# Altium schematic sheet ACW.SchDoc
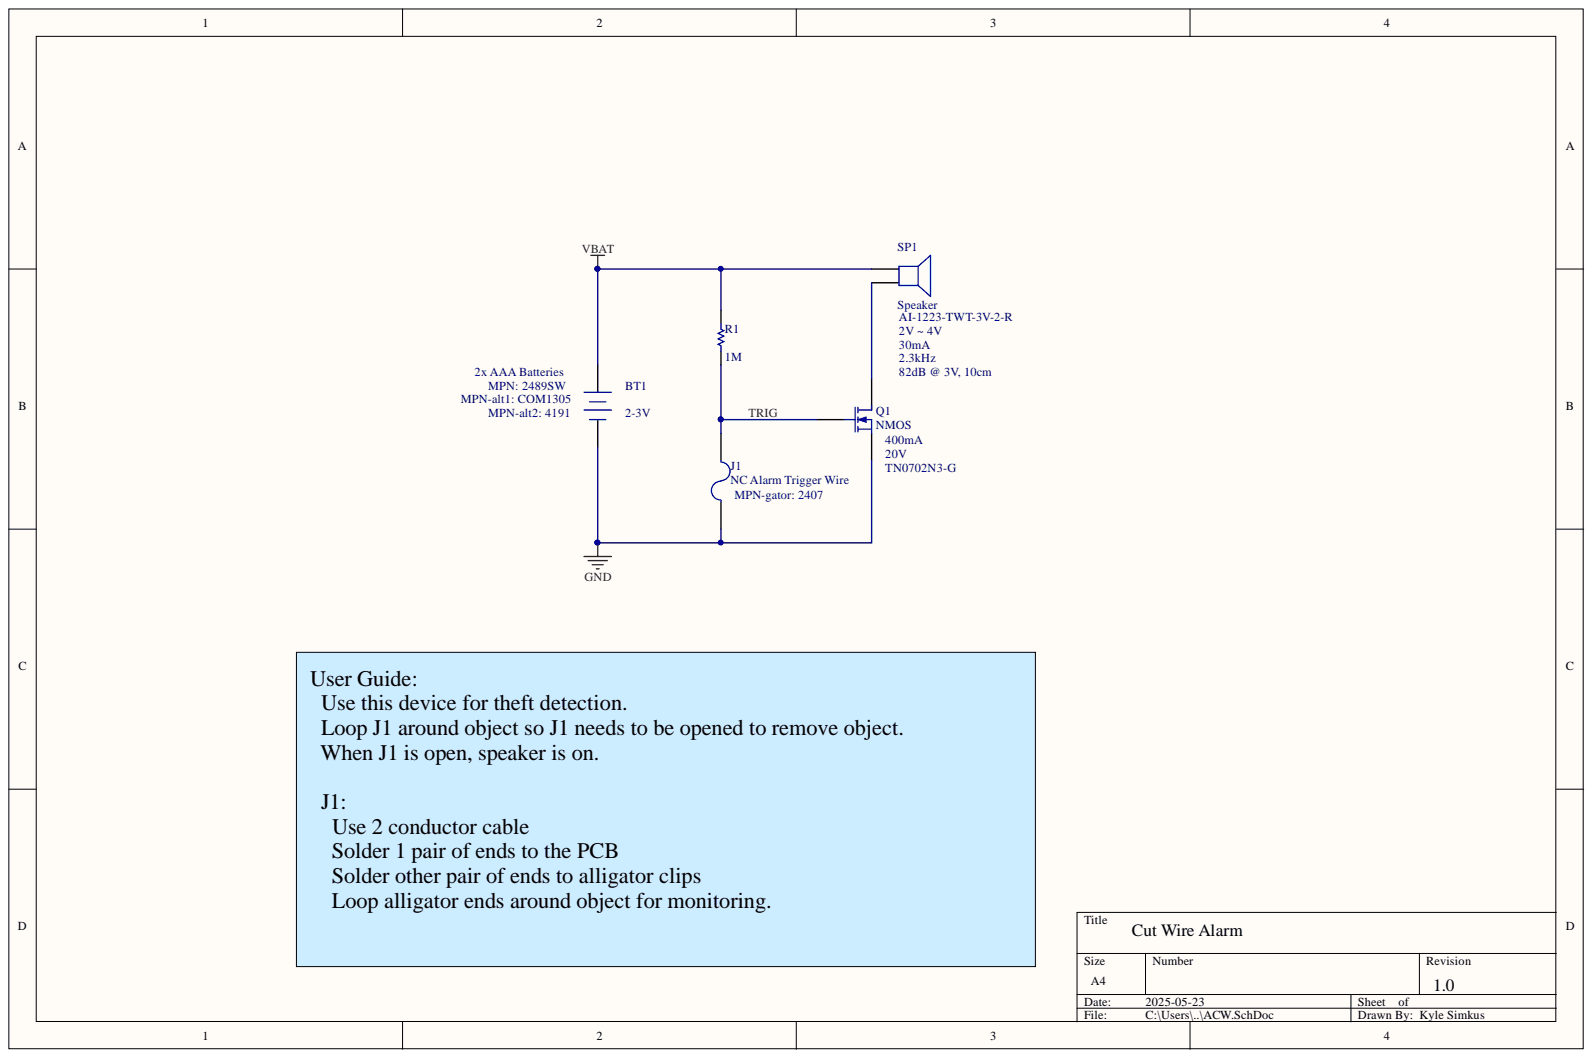
[diagram p0: ACW.SchDoc]

## Parts
BT1  2x AAA Batteries  MPN: 2489SW  MPN-alt2: 4191  Voltage: 2-3V  [BAT-2]
J1  NC Alarm Trigger Wire  [PIN-W2/E2.8]
Q1  NMOS  current: 400mA  MPN: TN0702N3-G  Vgs_max: 20V  [E3]
R1  value 1M  [AXIAL-0.5]  (hidden comment: Res Semi)
SP1  Speaker  MPN: AI-1223-TWT-3V-2-R  [SPKR-AI-1223-TWT-3V-2-R]

## Nets
GND: BT1.2 J1.1 Q1.3
VBAT: BT1.1 R1.2 SP1.1
TRIG: J1.2 Q1.2 R1.1
NetQ1_1: Q1.1 SP1.2

## Library data of the parts
BT1 = Battery: 2x AAA w switch; MPN-alt1: COM1305; Component Kind: Standard; Library Name: Miscellaneous Devices.IntLib
J1 = Fuse 2: MPN-gator: 2407; Component Kind: Standard; Library Name: Miscellaneous Devices.IntLib; Simulation: FUSE
Q1 = MOSFET-N: N-Channel MOSFET; MPN-9V: BS270; Component Kind: Standard; Library Name: Miscellaneous Devices.IntLib; MPN-2V: TN0702N3-G; Simulation: NMOS
R1 = Res Semi: Semiconductor Resistor; Component Kind: Standard; Library Name: Miscellaneous Devices.IntLib; Simulation: RES
SP1 = Speaker: Loudspeaker; current: 30mA; Frequency: 2.3kHz; rating: 82dB @ 3V, 10cm; Voltage: 2V ~ 4V; Component Kind: Standard; Library Name: Miscellaneous Devices.IntLib
